# Altium schematic sheet Pedal_SCH.SchDoc
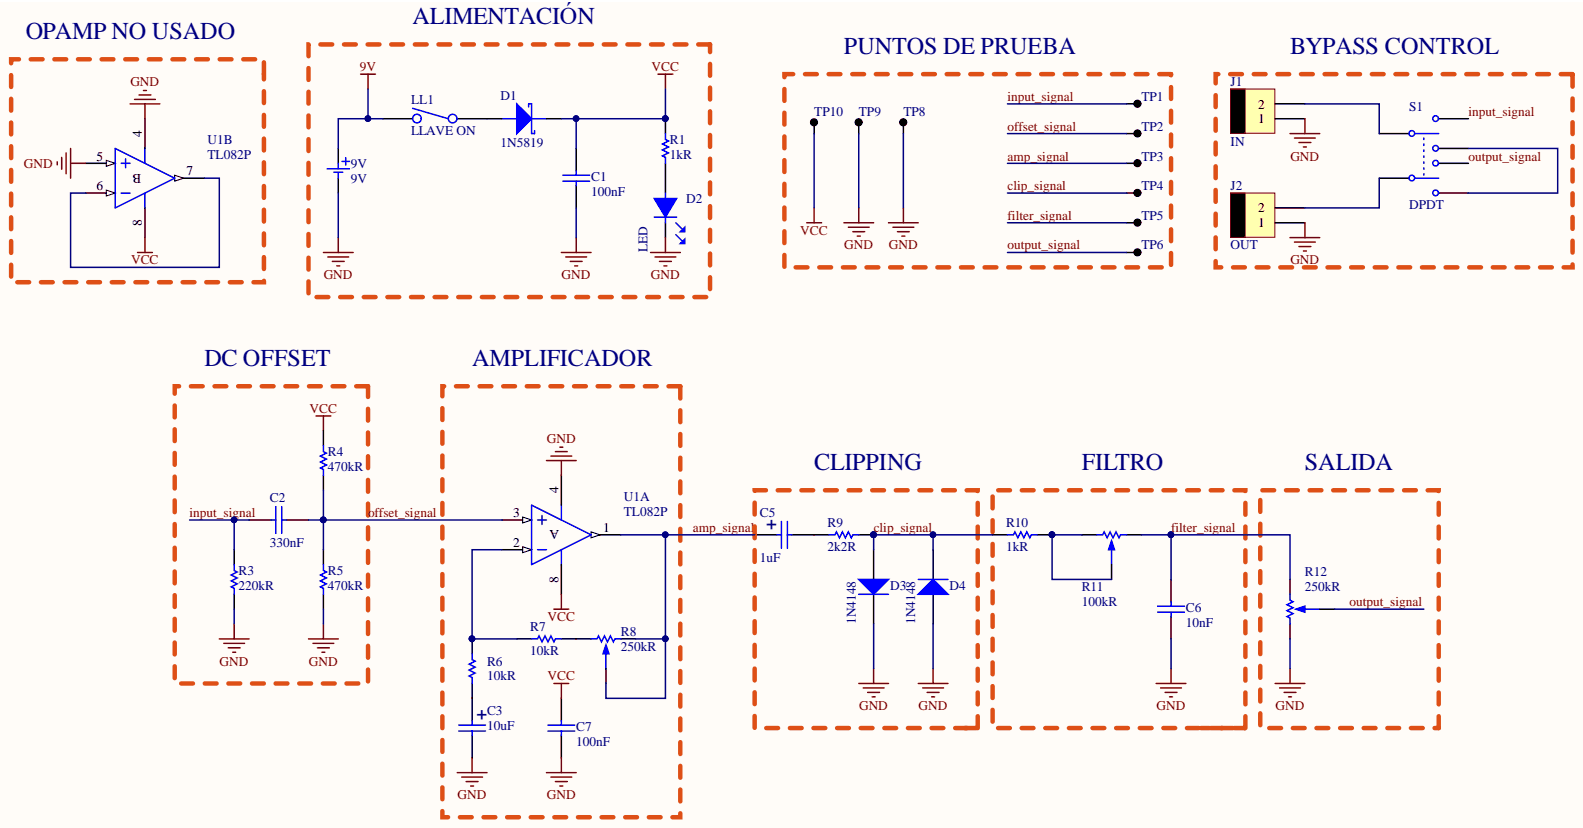
[diagram p0: Pedal_SCH.SchDoc]

## Parts
9V  9V
C1  100nF
C2  330nF
C3  10uF
C5  1uF
C6  10nF
C7  100nF
D1  1N5819
D2  LED
D3  1N4148
D4  1N4148
J1  IN
J2  OUT
LL1  LLAVE ON
R1  1kR
R3  220kR
R4  470kR
R5  470kR
R6  10kR
R7  10kR
R8  250kR
R9  2k2R
R10  1kR
R11  100kR
R12  250kR
S1  DPDT  [MOLEX6]
TP1  IN  [TPTH]  (value not drawn)
TP2  OFF  [TPTH]  (value not drawn)
TP3  AMP  [TPTH]  (value not drawn)
TP4  CLI  [TPTH]  (value not drawn)
TP5  FIL  [TPTH]  (value not drawn)
TP6  OUT  [TPTH]  (value not drawn)
TP8  GND  [TPTH]  (value not drawn)
TP9  GND  [TPTH]  (value not drawn)
TP10  VCC  [TPTH]  (value not drawn)
U1  TL082P  [P008]  (parts A,B)

## Nets
9V: 9V.1 LL1.2
GND: 9V.2 C1.2 C3.2 C6.2 C7.1 D2.2 D3.2 D4.1 J1.1 J2.1 R3.2 R5.2 R12.2 TP8.1 TP9.1 U1.4 U1.5
VCC: C1.1 C7.2 D1.K R1.1 R4.2 TP10.1 U1.8
AMP_SIGNAL: C5.1 R8.1 R8.2 TP3.1 U1.1
CLIP_SIGNAL: D3.1 D4.2 R9.2 R10.2 TP4.1
FILTER_SIGNAL: C6.1 R11.1 R12.1 TP5.1
INPUT_SIGNAL: C2.1 R3.1 S1.5 TP1.1
OFFSET_SIGNAL: C2.2 R4.1 R5.1 TP2.1 U1.3
OUTPUT_SIGNAL: R12.3 S1.2 TP6.1
NetC3_1: C3.1 R6.2
NetC5_2: C5.2 R9.1
NetD1_A: D1.A LL1.1
NetD2_1: D2.1 R1.2
NetJ1_2: J1.2 S1.6
NetJ2_2: J2.2 S1.1
NetR6_1: R6.1 R7.2 U1.2
NetR7_1: R7.1 R8.3
NetR10_1: R10.1 R11.2 R11.3
NetS1_3: S1.3 S1.4
NetU1_6: U1.6 U1.7

## Library data of the parts
9V = BATERIA: Value: MOLEX2; Component Kind: Standard; Description: BATERIA; Library Name: LIBEBAL.IntLib
C1 C2 C6 = CAP: Component Kind: Standard; Library Name: LIBEBAL.IntLib
  C1: Value: 100nF
  C2: Value: 330nF
  C6: Value: 10nF
C3 C5 = CAPPOL: Component Kind: Standard; Library Name: LIBEBAL.IntLib
  C3: Value: 10uF
  C5: Value: 1uF
C7 = CAP: Value: 330nF; Component Kind: Standard; Library Name: LIBEBAL.IntLib
D1 = DIODE SCHOTTKY: Component Kind: Standard; Library Name: LIB2013.IntLib
D2 = LED: Value: Led Verde 5mm; Component Kind: Standard; Library Name: LIBEBAL.IntLib
D3 D4 = Diode 1N4148: High Conductance Fast Diode; Component Kind: Standard; Library Name: Miscellaneous Devices.IntLib; Signal Integrity: 1N4148; Simulation: 1N4148; Value: 1N4148
J1 = MOLEX2: INPUT; Value: MOLEX2; Component Kind: Standard; Library Name: LIBEBAL.IntLib
J2 = MOLEX2: Value: MOLEX2; Component Kind: Standard; Description: OUT; Library Name: LIBEBAL.IntLib
R1 R3-R7 R9 R10 = RES: Component Kind: Standard; Library Name: LIBEBAL.IntLib
  R1 R10: Value: 1kR
  R3: Value: 220kR
  R4 R5: Value: 470kR
  R6 R7: Value: 10kR
  R9: Value: 2k2R
R8 R11 R12 = POTENCIOMETRO: Component Kind: Standard; Library Name: LIBEBAL.IntLib
  R8 R12: Value: 250kR
  R11: Value: 100kR
S1 = SW-DPDT: Double-Pole, Double-Throw Switch; Component Kind: Standard; LatestRevisionDate: 17-Jul-2002; LatestRevisionNote: Re-released for DXP Platform.; Library Name: Miscellaneous Devices.IntLib; PackageDescription: Switch; 6 Leads; PackageReference: DPDT-6; Published: 24-Mar-1999; Publisher: Altium Limited
TP1-TP6 TP8-TP10 = TP: Component Kind: Standard; Library Name: LIBEBAL.IntLib
U1 = TL082P: JFET-Input Operational Amplifier; Code_JEDEC: MO-001-AN; Component Kind: Standard; ComponentLink1Description: Manufacturer Link; ComponentLink1URL: http://www.ti.com/; ComponentLink2Description: Datasheet; ComponentLink2URL: http://www-s.ti.com/sc/ds/tl082.pdf; DatasheetVersion: Feb-1999; LatestRevisionDate: 04-Mar-2005; LatestRevisionNote: Stylized 3D Model Added.; PackageDescription: DIP; 8 Leads; Row Spacing 7.62 mm; Pitch 2.54 mm; PackageReference: P008; PackageVersion: Jun-1999; Published: 16-Jan-2001; Simulation: TL082; Library Name: Pedal_SCH.SCHLIB; Publisher: Altium Limited
LL1 = LLAVE ON: Component Kind: Standard; Description: Llave ON; Library Name: LIB2013.IntLib
